# Altium schematic sheet POWER.SchDoc
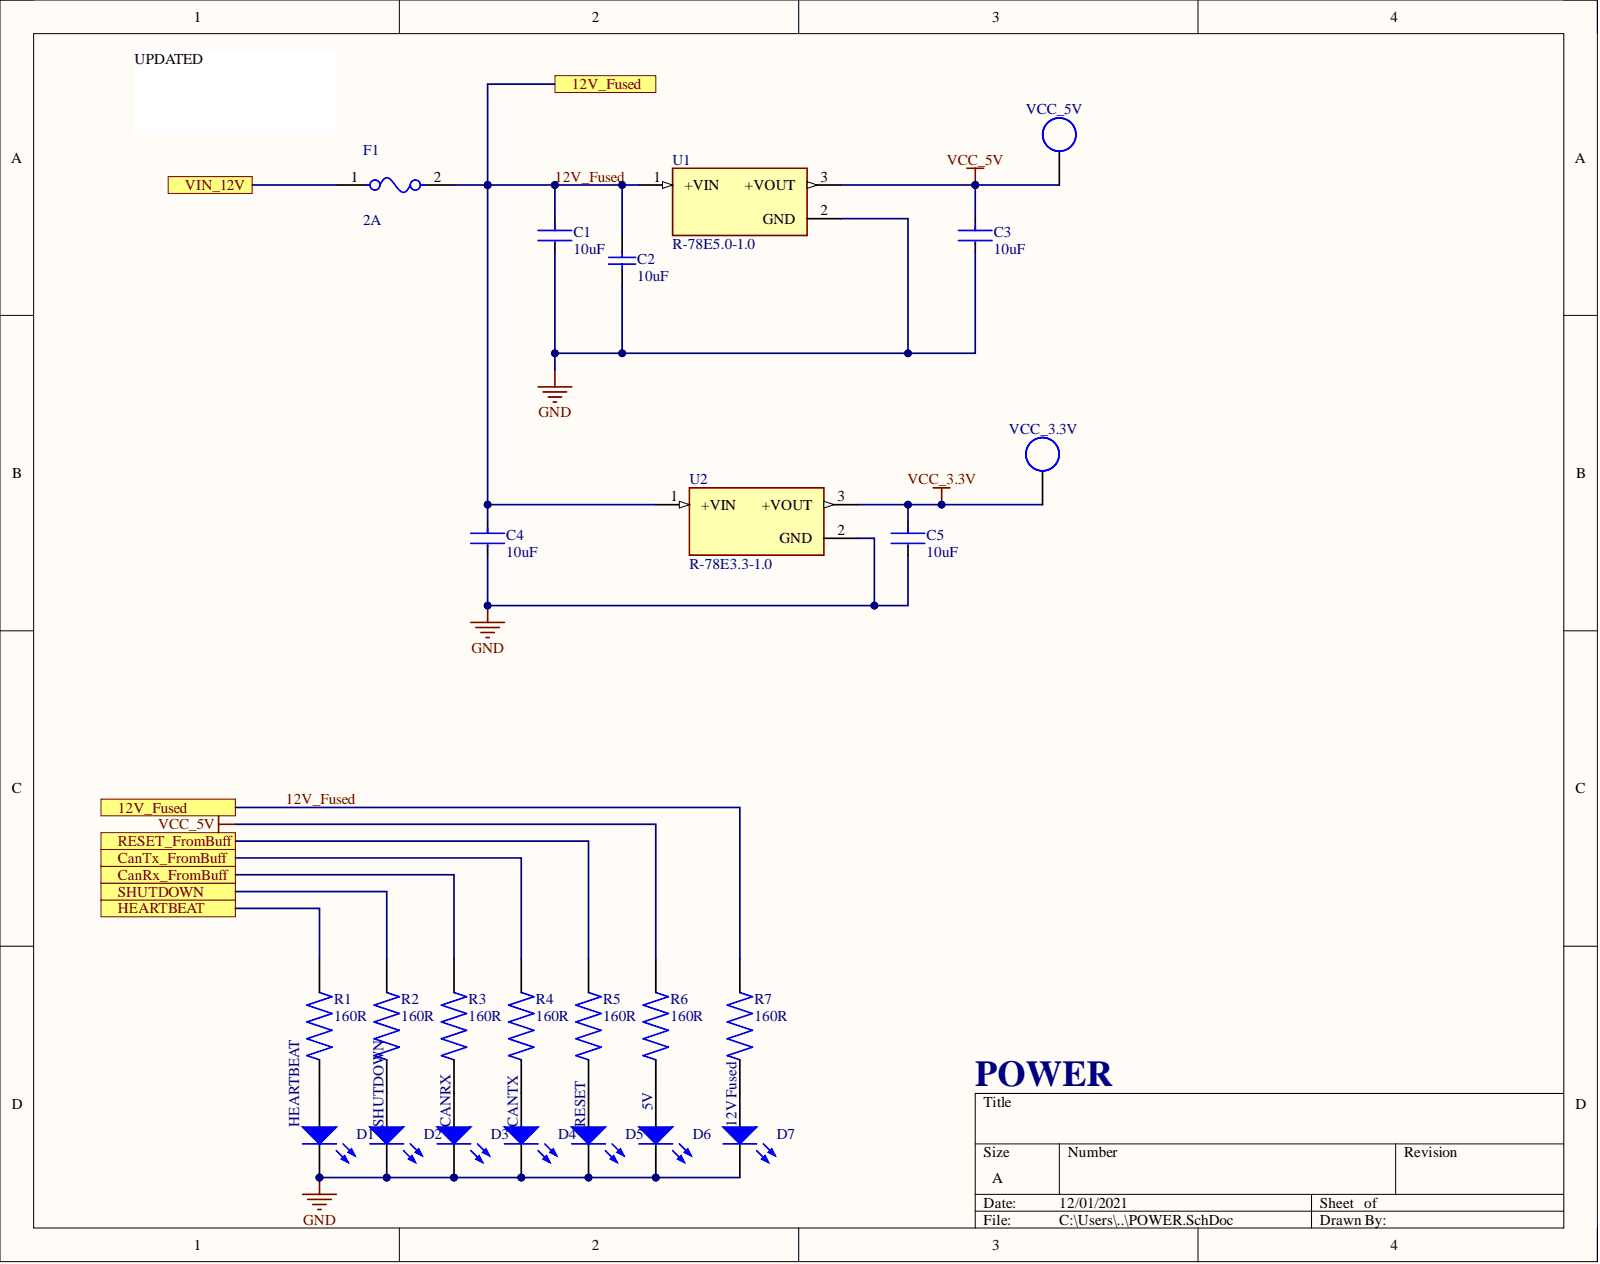
[diagram p0: POWER.SchDoc]

## Parts
C1  10uF  [FP-C2012-125-0_2-MFG]
C2  10uF  [CAPPRD200W50D550H1250]
C3  10uF  [FP-C2012-125-0_2-MFG]
C4  10uF  [FP-C2012-125-0_2-MFG]
C5  10uF  [FP-C2012-125-0_2-MFG]
D1  HEARTBEAT  [0805_A]
D2  SHUTDOWN  [0805_A]
D3  CANRX  [0805_A]
D4  CANTX  [0805_A]
D5  RESET  [0805_A]
D6  5V  [0805_A]
D7  12V Fused  [0805_A]
F1  2A  [FP-0154-MFG]
R1  Resistance: 160R  [RES_ERJP06J161V]  (hidden comment: ERJP06J161V)
R2  Resistance: 160R  [RES_ERJP06J161V]  (hidden comment: ERJP06J161V)
R3  Resistance: 160R  [RES_ERJP06J161V]  (hidden comment: ERJP06J161V)
R4  Resistance: 160R  [RES_ERJP06J161V]  (hidden comment: ERJP06J161V)
R5  Resistance: 160R  [RES_ERJP06J161V]  (hidden comment: ERJP06J161V)
R6  Resistance: 160R  [RES_ERJP06J161V]  (hidden comment: ERJP06J161V)
R7  Resistance: 160R  [RES_ERJP06J161V]  (hidden comment: ERJP06J161V)
U1  R-78E5.0-1.0  [CONV_R-78E5.0-1.0]
U2  R-78E3.3-1.0  [CONV_R-78E3.3-1.0]
VCC_3.3V  5015  [5015]  (value not drawn)
VCC_5V  5015  [5015]  (value not drawn)

## Ports
12V_Fused, CanRx_FromBuff, CanTx_FromBuff, HEARTBEAT, RESET_FromBuff, SHUTDOWN, VIN_12V

## Nets
GND: C1.2 C2.2 C3.2 C4.2 C5.2 D1.1 D2.1 D3.1 D4.1 D5.1 D6.1 D7.1 U1.2 U2.2
VCC_3.3V: C5.1 U2.3 VCC_3.3V.1
VCC_5V: C3.1 R6.2 U1.3 VCC_5V.1
12V_Fused: C1.1 C2.1 C4.1 F1.2 R7.2 U1.1 U2.1 ; port 12V_Fused
CanRx_FromBuff: R3.2 ; port CanRx_FromBuff
CanTx_FromBuff: R4.2 ; port CanTx_FromBuff
HEARTBEAT: R1.2 ; port HEARTBEAT
RESET_FromBuff: R5.2 ; port RESET_FromBuff
SHUTDOWN: R2.2 ; port SHUTDOWN
VIN_12V: F1.1 ; port VIN_12V
NetD1_2: D1.2 R1.1
NetD2_2: D2.2 R2.1
NetD3_2: D3.2 R3.1
NetD4_2: D4.2 R4.1
NetD5_2: D5.2 R5.1
NetD6_2: D6.2 R6.1
NetD7_2: D7.2 R7.1

## Library data of the parts
C1 C3-C5 = CMP-08246-003655-1: Multilayer Ceramic Capacitors 10μF ±10% 16V X6S SMD 0805; Capacitance: 10uF; Case Code (Imperial): 0805; Case Code (Metric): 2012; Case/Package: 0805; Component Kind: Standard; Construction: Flat; Contact Plating: Tin; Depth: 1.25mm; Dielectric: X6S; Dielectric Material: Ceramic; Height: 1.25mm; Lead Free: Lead Free; Length: 2mm; Library Name: Altium Content Vault; Max Operating Temperature: 105°C; Min Operating Temperature: -55°C; Mount: Surface Mount; Package Quantity: 1; Packaging: Tape and Reel; REACH SVHC: No SVHC; RoHS Compliant: Yes; Series: C; Supplier 1: Digi-Key; Supplier Part Number 1: 445-7611-1-ND; Thickness: 0.057inch; Tolerance: 10%; Voltage Rating: 16V; Voltage Rating (DC): 16V; Width: 0.049inch
C2 = Cap, TH, Electrolytic_5mm: Cap Alum 10UF 20% 25V Radial; Manufacturer 1: KEMET; Manufacturer Part Number 1: ESW106M025AC3AA; TargetLibrary: RITRacing-Passives; Value: Value?; Component Kind: Standard; Library Name: Passives.IntLib; Pricing 1: 1=0.23000, 10=0.15400, 50=0.09260, 100=0.07820, 500=0.05352, 1000=0.04735, 2500=0.04529, 5000=0.04117 (USD); Published: 22Oct2016; RoHS Compliant: Yes; Status: Active; Stock 1: 7476; Supplier 1: Digi-Key; Supplier Part Number 1: 399-ESW106M025AC3AA-ND; Publisher: T.Tallo
D1-D7 = CMP-1426-00009-1: SMD mono-color Chip LED, WL-SMCW, Bright Green; Manufacturer: Wuerth Elektronik; Manufacturer 1: Wurth Electronics; Manufacturer Part Number 1: 150080VS75000; Dominant Wavelength: 570nm; Forward Current: 30mA; Forward Voltage: 2V; Lens Style: Clear,Rectangular; Luminous Intensity: 40mcd; Test Current: 20mA; Viewing Angle: 140°; Case Code (Imperial): 0805; Case Code (Metric): 2012; Case/Package: 0805; Category: LEDs; Color: Bright Green; Component Kind: Standard; ComponentLink1Description: Manufacturer URL; ComponentLink1URL: http://www.we-online.com; ComponentLink2Description: Datasheet; ComponentLink2URL: http://katalog.we-online.com/led/datasheet/150080VS75000.pdf; ComponentLink3Description: https://datasheet.ciiva.com/22656/150080vs75000-22656966.pdf?src-supplier=Element14; ComponentLink3URL: https://datasheet.ciiva.com/22656/150080vs75000-22656966.pdf?src-supplier=Element14; ComponentLink4Description: https://datasheet.ciiva.com/29279/759437-da-01-en-chipled-0805-w-kl-h-gruen-150080vs7500-29279047.pdf?src-supplier=Conrad; ComponentLink4URL: https://datasheet.ciiva.com/29279/759437-da-01-en-chipled-0805-w-kl-h-gruen-150080vs7500-29279047.pdf?src-supplier=Conrad; ComponentLink5Description: https://datasheet.ciiva.com/29563/150080vs75000-29563018.pdf?src-supplier=Digi-Key; ComponentLink5URL: https://datasheet.ciiva.com/29563/150080vs75000-29563018.pdf?src-supplier=Digi-Key; Height: 0.7mm; Length: 2mm; Library Name: Altium Content Vault; Max Operating Temperature: 85°C; Min Operating Temperature: -40°C; Mount: Surface Mount; Package Quantity: 4000; PackageReference: 0805_A; Packaging: Tape and Reel; PartNumber: 150080VS75000; Pricing 1: 1=0.18000, 50=0.16500, 100=0.14200, 500=0.12300 (USD); REACH SVHC: Unknown; RoHS: Yes; Stock 1: 20997; Supplier 1: Digi-Key; Supplier Part Number 1: 732-4986-1-ND; Wavelength: 570nm; Width: 1.25mm
F1 = CMP-08605-000033-1: FUSE BOARD MNT 2A 125VAC/VDC SMD; Manufacturer: Littelfuse; Manufacturer Part Number: 0154002.DR; Fuse Blow Speed: Fast; Fuse I2T: 8.2A2Sec; Max Fuse Ac Voltage: 125V; Resistance: 0.0625Ω; Value: 0.0625Ω; Automotive: No; Category: Fuse; Component Kind: Standard; ComponentLink2Description: Datasheet; ComponentLink2URL: https://www.littelfuse.com/~/media/electronics/datasheets/fuses/littelfuse_fuse_154_154t_154l_154tl_datasheet.pdf.pdf; Device Class L1: Circuit Protection; Device Class L2: Fuses; Device Class L3: unset; Digikey Description: FUSE BOARD MNT 2A 125VAC/VDC SMD; Fuse Current: 2A; Height: 3.81mm; Lead Free: Yes; Library Name: Altium Content Vault; Max Fuse Dc Voltage: 125V; Mouser Description: 154 Series Fast-Acting 125 V 2 A 9.73 x 5.03 mm OMNI-BLOK® SMT Fuse & Holder; Octopart: https://octopart.com/0154002.dr-littelfuse-565858; Package: 0154; RoHS: TRUE; Supplier 1: Digi-Key; Supplier Part Number 1: F1224CT-ND; Temperature: -55°C to +125°C; Temperature Range High: +125°C; Temperature Range Low: -55°C; Voltage Rating: 125V
R1-R6 = ERJP06J161V: RES SMD 160 OHM 5% 1/2W 0805; Manufacturer 1: Panasonic; Manufacturer Part Number 1: ERJ-P06J161V; Max Power Dissipation: 500mW; Temperature Coefficient: 200ppm/°C; Voltage Rating: 150V; Availability: Unavailable; Case Code (Imperial): 0805; Case Code (Metric): 2012; Case/Package: 0805; Category: Chip SMD Resistors; Component Kind: Standard; ComponentLink1Description: http://www.mouser.com/ds/2/315/AOA0000CE4-64075.pdf?src-supplier=Mouser; ComponentLink1URL: http://www.mouser.com/ds/2/315/AOA0000CE4-64075.pdf?src-supplier=Mouser; ComponentLink2Description: http://www.onlinecomponents.com/datasheet/erj-p06j161v.aspx?p=44459141&src-supplier=Onlinecomponents.com; ComponentLink2URL: http://www.onlinecomponents.com/datasheet/erj-p06j161v.aspx?p=44459141&src-supplier=Onlinecomponents.com; ComponentLink3Description: https://industrial.panasonic.com/cdbs/www-data/pdf/RDO0000/AOA0000C331.pdf?src-supplier=Digi-Key; ComponentLink3URL: https://industrial.panasonic.com/cdbs/www-data/pdf/RDO0000/AOA0000C331.pdf?src-supplier=Digi-Key; ComponentLink4Description: https://industrial.panasonic.com/ww/system/files/data/download/catalog/id_fixed-resistors_e_1.pdf?src-supplier=Digi-Key; ComponentLink4URL: https://industrial.panasonic.com/ww/system/files/data/download/catalog/id_fixed-resistors_e_1.pdf?src-supplier=Digi-Key; ComponentLink5Description: http://industrial.panasonic.com/www-data/pdf/AOA0000/AOA0000CE4.pdf?src-supplier=Avnet; ComponentLink5URL: http://industrial.panasonic.com/www-data/pdf/AOA0000/AOA0000CE4.pdf?src-supplier=Avnet; ComponentLink6Description: http://industrial.panasonic.com/www-data/pdf/AOA0000/AOA0000CE4.pdf?src-supplier=Avnet+Europe; ComponentLink6URL: http://industrial.panasonic.com/www-data/pdf/AOA0000/AOA0000CE4.pdf?src-supplier=Avnet+Europe; Contact Plating: Tin; Features: Automotive AEC-Q200; Height: 0.028inch; Lead Free: Contains Lead; Length: 0.079inch; Max Operating Temperature: 155°C; Min Operating Temperature: -55°C; Mount: Surface Mount; MP: ERJP06J161V; Number of Terminations: 2; Package: None; Package Quantity: 5000; Packaging: Tape and Reel; Power Rating: 500mW; Price: None; Pricing 1: 1=0.12000, 10=0.10800, 100=0.04140, 1000=0.01822, 2500=0.01573 (USD); Radiation Hardening: No; Resistor Type: Thick Film; RoHS: Yes; RoHS Compliant: Yes; Series: ERJ; Stock 1: 25408; Supplier 1: Digi-Key; Supplier Part Number 1: P160ADCT-ND; Tolerance: 5%; Voltage Rating (DC): 150V; Width: 1.2mm; Library Name: BMS_MasterboardUpdated_1.SCHLIB
R7 = ERJP06J161V: RES SMD 665 OHM 1% 1/2W 0805; Manufacturer 1: Panasonic; Manufacturer Part Number 1: ERJ-P06F6650V; Max Power Dissipation: 500mW; Temperature Coefficient: 200ppm/°C; Tolerance: 5%; Voltage Rating: 150V; Availability: Unavailable; Case Code (Imperial): 0805; Case Code (Metric): 2012; Case/Package: 0805; Category: Chip SMD Resistors; Component Kind: Standard; ComponentLink1Description: http://www.mouser.com/ds/2/315/AOA0000CE4-64075.pdf?src-supplier=Mouser; ComponentLink1URL: http://www.mouser.com/ds/2/315/AOA0000CE4-64075.pdf?src-supplier=Mouser; ComponentLink2Description: http://www.onlinecomponents.com/datasheet/erj-p06j161v.aspx?p=44459141&src-supplier=Onlinecomponents.com; ComponentLink2URL: http://www.onlinecomponents.com/datasheet/erj-p06j161v.aspx?p=44459141&src-supplier=Onlinecomponents.com; ComponentLink3Description: https://industrial.panasonic.com/cdbs/www-data/pdf/RDO0000/AOA0000C331.pdf?src-supplier=Digi-Key; ComponentLink3URL: https://industrial.panasonic.com/cdbs/www-data/pdf/RDO0000/AOA0000C331.pdf?src-supplier=Digi-Key; ComponentLink4Description: https://industrial.panasonic.com/ww/system/files/data/download/catalog/id_fixed-resistors_e_1.pdf?src-supplier=Digi-Key; ComponentLink4URL: https://industrial.panasonic.com/ww/system/files/data/download/catalog/id_fixed-resistors_e_1.pdf?src-supplier=Digi-Key; ComponentLink5Description: http://industrial.panasonic.com/www-data/pdf/AOA0000/AOA0000CE4.pdf?src-supplier=Avnet; ComponentLink5URL: http://industrial.panasonic.com/www-data/pdf/AOA0000/AOA0000CE4.pdf?src-supplier=Avnet; ComponentLink6Description: http://industrial.panasonic.com/www-data/pdf/AOA0000/AOA0000CE4.pdf?src-supplier=Avnet+Europe; ComponentLink6URL: http://industrial.panasonic.com/www-data/pdf/AOA0000/AOA0000CE4.pdf?src-supplier=Avnet+Europe; ComponentLink7Description: https://datasheet.ciiva.com/24126/aoa0000ce4-64075-24126826.pdf?src-supplier=Mouser; ComponentLink7URL: https://datasheet.ciiva.com/24126/aoa0000ce4-64075-24126826.pdf?src-supplier=Mouser; ComponentLink8Description: https://datasheet.ciiva.com/22721/1801181-22721149.pdf?src-supplier=Farnell; ComponentLink8URL: https://datasheet.ciiva.com/22721/1801181-22721149.pdf?src-supplier=Farnell; ComponentLink9Description: https://datasheet.ciiva.com/46383/1801181-46383229.pdf?src-supplier=Element14; ComponentLink9URL: https://datasheet.ciiva.com/46383/1801181-46383229.pdf?src-supplier=Element14; Contact Plating: Tin; Features: Automotive AEC-Q200; Height: 0.028inch; Lead Free: Contains Lead; Length: 0.079inch; Max Operating Temperature: 155°C; Min Operating Temperature: -55°C; Mount: Surface Mount; MP: ERJP06J161V; Number of Terminations: 2; Package: None; Package Quantity: 5000; Packaging: Tape and Reel; Power Rating: 500mW; Price: None; Pricing 1: 1=0.18000, 10=0.15400, 100=0.06030, 1000=0.02525, 2500=0.02315 (USD); Radiation Hardening: No; REACH SVHC: No SVHC; Resistor Type: Thick Film; RoHS: Yes; RoHS Compliant: Yes; Series: ERJ; Stock 1: 364; Supplier 1: Digi-Key; Supplier Part Number 1: P16076CT-ND; Termination: SMD/SMT; Voltage Rating (DC): 150V; Weight: 0.000194oz; Width: 1.2mm; Library Name: BMS_MasterboardUpdated_1.SCHLIB
U1 = R-78E5.0-1.0: 1A DC/DC-Converter 'INNOLINE' SIP3 reg; MF: Recom Power; Availability: Unavailable; Component Kind: Standard; MP: R-78E5.0-1.0; Package: SIP-3 Recom Power; Price: None; SnapEDA_Link: https://www.snapeda.com/parts/R-78E5.0-1.0/Recom%20Power/view-part/557753/?ref=snap; Supplier 1: Digi-Key; Supplier Part Number 1: 945-2201-ND; Library Name: BMS_MasterboardUpdated_1.SCHLIB
U2 = R-78E3.3-1.0: 1A DC/DC-Converter 'INNOLINE' SIP3 reg; MF: Recom Power; Component Kind: Standard; Digi-Key_Part_Number: 945-2409-5-ND; Digi-Key_Purchase_URL: https://www.digikey.com/en/products/detail/recom-power/R-78E3-3-1-0/5327711; MP: R-78E3.3-1.0; Package: SIP-3 Recom Power; SnapEDA_Link: https://www.snapeda.com/parts/R-78E3.3-0.5/Recom%20Power/view-part/229066/?ref=snap; Supplier 1: Digi-Key; Supplier Part Number 1: 945-2409-5-ND; Library Name: BMS_MasterboardUpdated_1.SCHLIB
VCC_3.3V VCC_5V = 5015: SMD Test Point; Manufacturer: Keystone Electronics; TargetLibrary: RITRacing-Connectors; Component Kind: Standard; ComponentLink1Description: Datasheet; ComponentLink1URL: http://www.mouser.com/ds/2/215/005-5009-743680.pdf; Library Name: Connectors.IntLib; PartNumber: 5015; Published: 22Oct2016; Status: Active; Publisher: T.Tallo
